# Altium schematic sheet HVM.SchDoc(HVM)
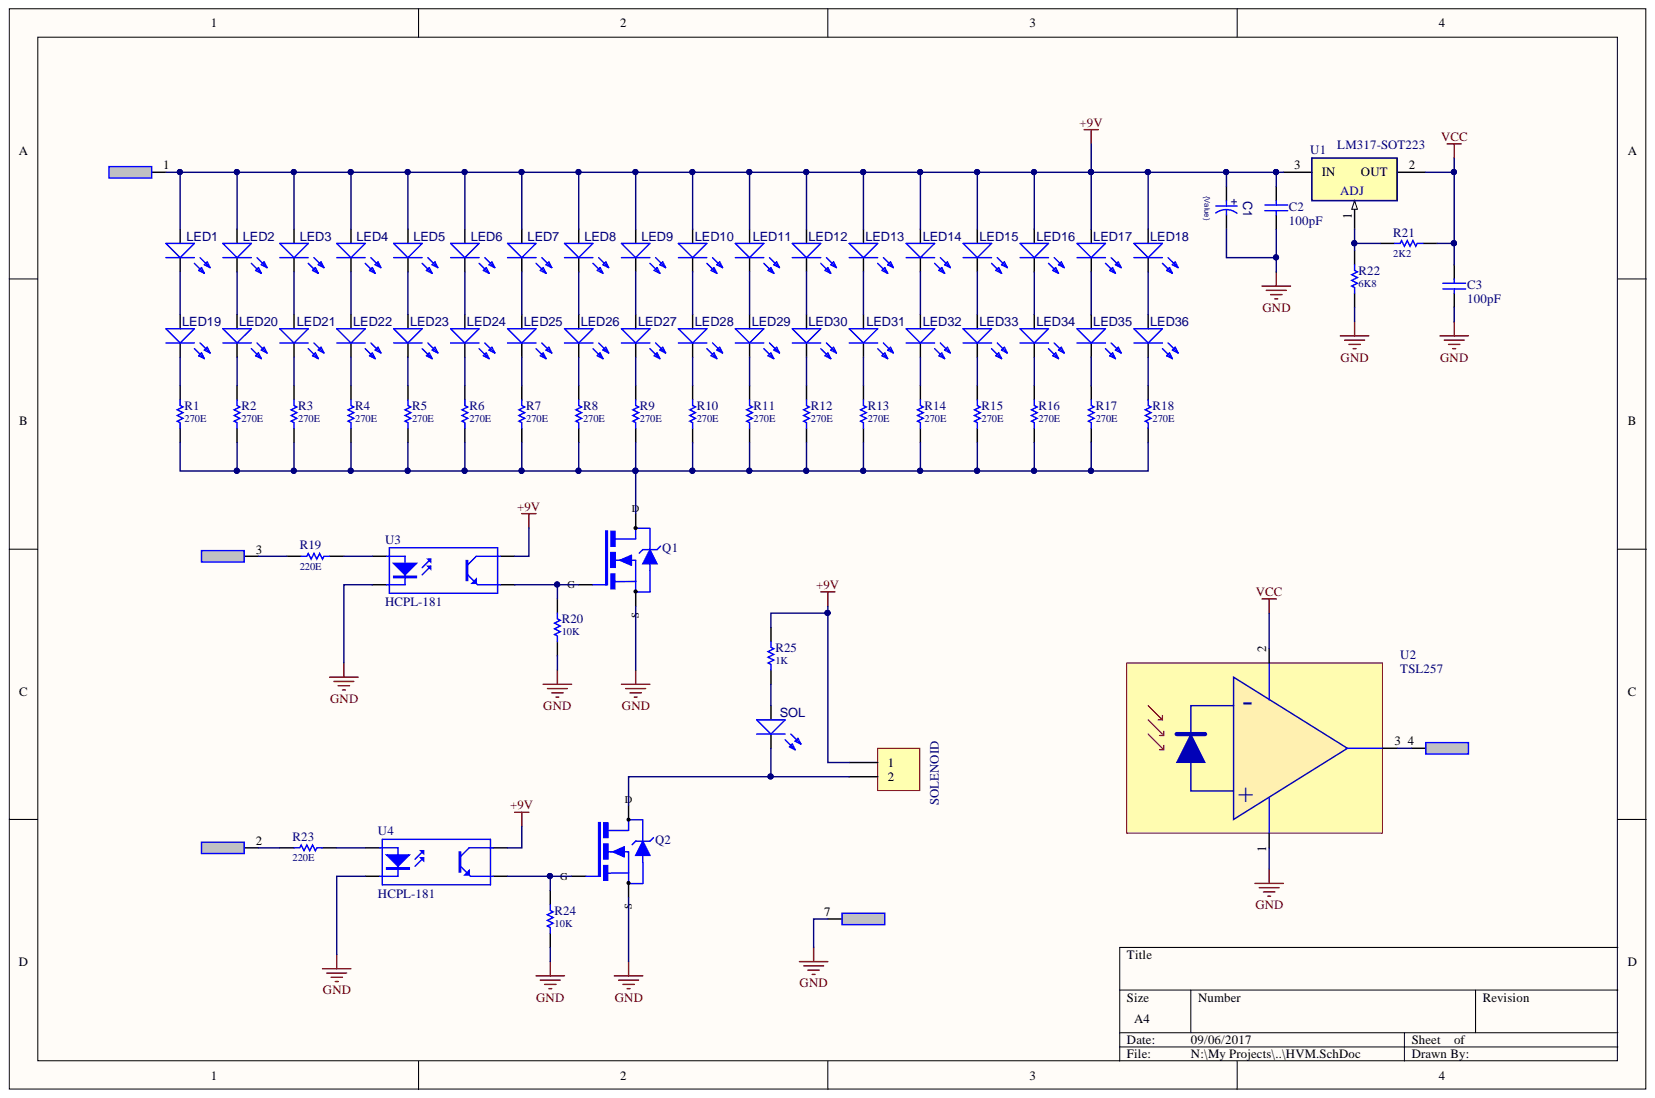
[diagram p0: HVM.SchDoc]

## Parts
C1  value {Value}  [C-TANT#C]  (hidden comment: Cap Tantalum TH)
C2  value 100pF  [C-0805]  (hidden comment: Cap 0805)
C3  value 100pF  [C-0805]  (hidden comment: Cap 0805)
HVM_&_EB  IDC7M  [HDR1X7-Male]  (value not drawn)  (parts A,B,C,D,G)
LED1  LED-3mm_R  [LED3mm-Through Hole]  (value not drawn)
LED2  LED-3mm_R  [LED3mm-Through Hole]  (value not drawn)
LED3  LED-3mm_R  [LED3mm-Through Hole]  (value not drawn)
LED4  LED-3mm_R  [LED3mm-Through Hole]  (value not drawn)
LED5  LED-3mm_R  [LED3mm-Through Hole]  (value not drawn)
LED6  LED-3mm_R  [LED3mm-Through Hole]  (value not drawn)
LED7  LED-3mm_R  [LED3mm-Through Hole]  (value not drawn)
LED8  LED-3mm_R  [LED3mm-Through Hole]  (value not drawn)
LED9  LED-3mm_R  [LED3mm-Through Hole]  (value not drawn)
LED10  LED-3mm_R  [LED3mm-Through Hole]  (value not drawn)
LED11  LED-3mm_R  [LED3mm-Through Hole]  (value not drawn)
LED12  LED-3mm_R  [LED3mm-Through Hole]  (value not drawn)
LED13  LED-3mm_R  [LED3mm-Through Hole]  (value not drawn)
LED14  LED-3mm_R  [LED3mm-Through Hole]  (value not drawn)
LED15  LED-3mm_R  [LED3mm-Through Hole]  (value not drawn)
LED16  LED-3mm_R  [LED3mm-Through Hole]  (value not drawn)
LED17  LED-3mm_R  [LED3mm-Through Hole]  (value not drawn)
LED18  LED-3mm_R  [LED3mm-Through Hole]  (value not drawn)
LED19  LED-3mm_R  [LED3mm-Through Hole]  (value not drawn)
LED20  LED-3mm_R  [LED3mm-Through Hole]  (value not drawn)
LED21  LED-3mm_R  [LED3mm-Through Hole]  (value not drawn)
LED22  LED-3mm_R  [LED3mm-Through Hole]  (value not drawn)
LED23  LED-3mm_R  [LED3mm-Through Hole]  (value not drawn)
LED24  LED-3mm_R  [LED3mm-Through Hole]  (value not drawn)
LED25  LED-3mm_R  [LED3mm-Through Hole]  (value not drawn)
LED26  LED-3mm_R  [LED3mm-Through Hole]  (value not drawn)
LED27  LED-3mm_R  [LED3mm-Through Hole]  (value not drawn)
LED28  LED-3mm_R  [LED3mm-Through Hole]  (value not drawn)
LED29  LED-3mm_R  [LED3mm-Through Hole]  (value not drawn)
LED30  LED-3mm_R  [LED3mm-Through Hole]  (value not drawn)
LED31  LED-3mm_R  [LED3mm-Through Hole]  (value not drawn)
LED32  LED-3mm_R  [LED3mm-Through Hole]  (value not drawn)
LED33  LED-3mm_R  [LED3mm-Through Hole]  (value not drawn)
LED34  LED-3mm_R  [LED3mm-Through Hole]  (value not drawn)
LED35  LED-3mm_R  [LED3mm-Through Hole]  (value not drawn)
LED36  LED-3mm_R  [LED3mm-Through Hole]  (value not drawn)
Q1  NTD4909NT4G  [DPAK]  (value not drawn)
Q2  NTD4909NT4G  [DPAK]  (value not drawn)
R1  value 270E  [R1206]  (hidden comment: Resistor - SMD 0805)
R2  value 270E  [R1206]  (hidden comment: Resistor - SMD 0805)
R3  value 270E  [R1206]  (hidden comment: Resistor - SMD 0805)
R4  value 270E  [R1206]  (hidden comment: Resistor - SMD 0805)
R5  value 270E  [R1206]  (hidden comment: Resistor - SMD 0805)
R6  value 270E  [R1206]  (hidden comment: Resistor - SMD 0805)
R7  value 270E  [R1206]  (hidden comment: Resistor - SMD 0805)
R8  value 270E  [R1206]  (hidden comment: Resistor - SMD 0805)
R9  value 270E  [R1206]  (hidden comment: Resistor - SMD 0805)
R10  value 270E  [R1206]  (hidden comment: Resistor - SMD 0805)
R11  value 270E  [R1206]  (hidden comment: Resistor - SMD 0805)
R12  value 270E  [R1206]  (hidden comment: Resistor - SMD 0805)
R13  value 270E  [R1206]  (hidden comment: Resistor - SMD 0805)
R14  value 270E  [R1206]  (hidden comment: Resistor - SMD 0805)
R15  value 270E  [R1206]  (hidden comment: Resistor - SMD 0805)
R16  value 270E  [R1206]  (hidden comment: Resistor - SMD 0805)
R17  value 270E  [R1206]  (hidden comment: Resistor - SMD 0805)
R18  value 270E  [R1206]  (hidden comment: Resistor - SMD 0805)
R19  value 220E  [R-0805 - 220E]  (hidden comment: Resistor - SMD 0805)
R20  value 10K  [R-0805 - 10K]  (hidden comment: Resistor - SMD 0805)
R21  value 2K2  [R-0805 - 2K2]  (hidden comment: Resistor - SMD 0805)
R22  value 6K8  [R-0805 - 6K8]  (hidden comment: Resistor - SMD 0805)
R23  value 220E  [R-0805 - 220E]  (hidden comment: Resistor - SMD 0805)
R24  value 10K  [R-0805 - 10K]  (hidden comment: Resistor - SMD 0805)
R25  value 1K  [R-0805 - 1K]  (hidden comment: Resistor - SMD 0805)
SOL  LED-SMD_0805-RED  [LED3mm-Through Hole]  (value not drawn)
SOLENOID  Header 2  [HDR1X2]  (value not drawn)
U1  LM317-SOT223  [LM317_SOT-223]
U2  TSL257  [TSL257-TH]
U3  HCPL-181  [PC357]
U4  HCPL-181  [PC357]

## Nets
+9V: C1.PLUS C2.2 HVM_&_EB.1 LED1.2 LED2.2 LED3.2 LED4.2 LED5.2 LED6.2 LED7.2 LED8.2 LED9.2 LED10.2 LED11.2 LED12.2 LED13.2 LED14.2 LED15.2 LED16.2 LED17.2 LED18.2 R25.2 SOLENOID.1 U1.3 U3.4 U4.4
GND: C1.MINUS C2.1 C3.1 HVM_&_EB.7 Q1.2 Q2.2 R20.1 R22.2 R24.1 U2.1 U3.2 U4.2
VCC: C3.2 R21.1 U1.2 U2.2
NetHVM & EB_2: HVM_&_EB.2 R23.1
NetHVM & EB_3: HVM_&_EB.3 R19.1
NetHVM & EB_4: HVM_&_EB.4 U2.3
NetLED1_1: LED1.1 LED19.2
NetLED2_1: LED2.1 LED20.2
NetLED3_1: LED3.1 LED21.2
NetLED4_1: LED4.1 LED22.2
NetLED5_1: LED5.1 LED23.2
NetLED6_1: LED6.1 LED24.2
NetLED7_1: LED7.1 LED25.2
NetLED8_1: LED8.1 LED26.2
NetLED9_1: LED9.1 LED27.2
NetLED10_1: LED10.1 LED28.2
NetLED11_1: LED11.1 LED29.2
NetLED12_1: LED12.1 LED30.2
NetLED13_1: LED13.1 LED31.2
NetLED14_1: LED14.1 LED32.2
NetLED15_1: LED15.1 LED33.2
NetLED16_1: LED16.1 LED34.2
NetLED17_1: LED17.1 LED35.2
NetLED18_1: LED18.1 LED36.2
NetLED19_1: LED19.1 R1.2
NetLED20_1: LED20.1 R2.2
NetLED21_1: LED21.1 R3.2
NetLED22_1: LED22.1 R4.2
NetLED23_1: LED23.1 R5.2
NetLED24_1: LED24.1 R6.2
NetLED25_1: LED25.1 R7.2
NetLED26_1: LED26.1 R8.2
NetLED27_1: LED27.1 R9.2
NetLED28_1: LED28.1 R10.2
NetLED29_1: LED29.1 R11.2
NetLED30_1: LED30.1 R12.2
NetLED31_1: LED31.1 R13.2
NetLED32_1: LED32.1 R14.2
NetLED33_1: LED33.1 R15.2
NetLED34_1: LED34.1 R16.2
NetLED35_1: LED35.1 R17.2
NetLED36_1: LED36.1 R18.2
NetQ1_1: Q1.1 R20.2 U3.3
NetQ1_3: Q1.3 R1.1 R2.1 R3.1 R4.1 R5.1 R6.1 R7.1 R8.1 R9.1 R10.1 R11.1 R12.1 R13.1 R14.1 R15.1 R16.1 R17.1 R18.1
NetQ2_1: Q2.1 R24.2 U4.3
NetQ2_3: Q2.3 SOL.1 SOLENOID.2
NetR19_2: R19.2 U3.1
NetR21_2: R21.2 R22.1 U1.1
NetR23_2: R23.2 U4.1
NetR25_1: R25.1 SOL.2

## Library data of the parts
C1 = C-TANT#C: Tantalum Capacitor SMD; Component Kind: Standard; Library Name: MKM Capacitors.IntLib
C2 C3 = Cap 0805: Capacitor SMD; Published: 8-Jun-2000; PackageReference: C1206; LatestRevisionNote: Re-released for DXP Platform.; LatestRevisionDate: 17-Jul-2002; Component Kind: Standard; Publisher: Altium Limited; Library Name: MKM Capacitors.IntLib
HVM_&_EB = CON7M_SIL: amtec 8Ways  Male Connector Straight  Single In Line; Component Kind: Standard; Library Name: MKM Connectors.IntLib
LED1-LED36 = LED-3mm_R: LED 3mm Red; Vendor P/N: QTLP610C-AG; Vendor: Fairchild; CR: VV; CH: WL-08-2004; Assy: Loaded; Component Kind: Standard; ComponentHeight: 1.10mm; Library Name: MKM Optoelectronics.IntLib
R1-R18 = R0805- 270E: Simulation: RESISTOR; Signal Integrity: Res; Published: 8-Jun-2000; PackageReference: AXIAL-0.3; LatestRevisionNote: Re-released for DXP Platform.; LatestRevisionDate: 17-Jul-2002; Description: Resistor - SMD 0805; Component Kind: Standard; Publisher: Altium Limited; Library Name: MKM Resistors.IntLib
R19 R23 = R0805- 220E: Simulation: RESISTOR; Signal Integrity: Res; Published: 8-Jun-2000; PackageReference: AXIAL-0.3; LatestRevisionNote: Re-released for DXP Platform.; LatestRevisionDate: 17-Jul-2002; Description: Resistor - SMD 0805; Component Kind: Standard; Publisher: Altium Limited; Library Name: MKM Resistors.IntLib
R20 R24 = R0805- 10K: Simulation: RESISTOR; Signal Integrity: Res; Published: 8-Jun-2000; PackageReference: AXIAL-0.3; LatestRevisionNote: Re-released for DXP Platform.; LatestRevisionDate: 17-Jul-2002; Description: Resistor - SMD 0805; Component Kind: Standard; Publisher: Altium Limited; Library Name: MKM Resistors.IntLib
R21 = R0805- 2K2: Simulation: RESISTOR; Signal Integrity: Res; Published: 8-Jun-2000; PackageReference: AXIAL-0.3; LatestRevisionNote: Re-released for DXP Platform.; LatestRevisionDate: 17-Jul-2002; Description: Resistor - SMD 0805; Component Kind: Standard; Publisher: Altium Limited; Library Name: MKM Resistors.IntLib
R22 = R0805- 6K8: Simulation: RESISTOR; Signal Integrity: Res; Published: 8-Jun-2000; PackageReference: AXIAL-0.3; LatestRevisionNote: Re-released for DXP Platform.; LatestRevisionDate: 17-Jul-2002; Description: Resistor - SMD 0805; Component Kind: Standard; Publisher: Altium Limited; Library Name: MKM Resistors.IntLib
R25 = R0805- 1K: Simulation: RESISTOR; Signal Integrity: Res; Published: 8-Jun-2000; PackageReference: AXIAL-0.3; LatestRevisionNote: Re-released for DXP Platform.; LatestRevisionDate: 17-Jul-2002; Description: Resistor - SMD 0805; Component Kind: Standard; Publisher: Altium Limited; Library Name: MKM Resistors.IntLib
SOL = LED-SMD_0805-RED: LED 0805 Red; Vendor P/N: QTLP610C-AG; Vendor: Fairchild; CR: VV; CH: WL-08-2004; Assy: Loaded; Component Kind: Standard; ComponentHeight: 1.10mm; Library Name: MKM Optoelectronics.IntLib
SOLENOID = Header 2: Header, 2-Pin; Signal Integrity: Connector; LatestRevisionNote: Re-released for DXP Platform.; LatestRevisionDate: 17-Jul-2002; Component Kind: Standard; Publisher: Altium Limited; Library Name: EOT.IntLib
U1 = LM317-SOT223: Adjustable Regulator with 3.7 to 38 V Input and 1.2 to 32 V Output, -40 to 125 degC,; PartNumber: LM317KC; Manufacturer: Texas Instruments; Vout(Min)(V): 1.2; Vout(Max)(V): 32; Vin(Min)(V): 3.7; Vin(Max)(V): 38; Iout(Max)(A): 0.1; Eco-Plan: Pb-Free (RoHS); Supplier Part Number 2: 595-LM317LILPRE3; Supplier Part Number 1: LM317LILPRE3-ND; Supplier 2: Mouser; Supplier 1: Digi-Key; PackageVersion: revC, Oct-2001; PackageReference: LP3; PackageDescription: Plastic Cylindrical Package, Formed Lead Option (TO-92); Mounting Technology: Through Hole; DatasheetVersion: SLCS144D; ComponentLink3URL: Samples URL:http://www.ti.com/product/lm317l#samples; ComponentLink3Description: URL Link1; ComponentLink2URL: http://www.ti.com/litv/pdf/msot002a; ComponentLink2Description: Package Specification; ComponentLink1URL: http://www.ti.com/general/docs/lit/getliterature.tsp?genericPartNumber=LM317L&fileType=pdf; ComponentLink1Description: Datasheet; Component Kind: Standard; Code_JEDEC: TO-226-AA; Library Name: MKM Voltage regulators.IntLib
U2 = TSL257: Photo diode (Light Sensor); Component Kind: Standard; Library Name: MKM Optoelectronics.IntLib
U3 U4 = HCPL181: 4-Pin Phototransistor Optocoupler; Published: 24-Mar-1999; PackageReference: DIP-4; LatestRevisionNote: Sch pins 3 & 4 Swapped.; LatestRevisionDate: 04-Mar-2005; DatasheetDocument: Nov-2003; Component Kind: Standard; Publisher: Altium Limited; Library Name: MKM Optoelectronics.IntLib
Q1 Q2 = NTD4909NT4G: Description: NTD4909NT4G; Component Kind: Standard; Library Name: MKM Transistors.IntLib
